# Altium schematic sheet [04] - RAM INTERFACE.SchDoc
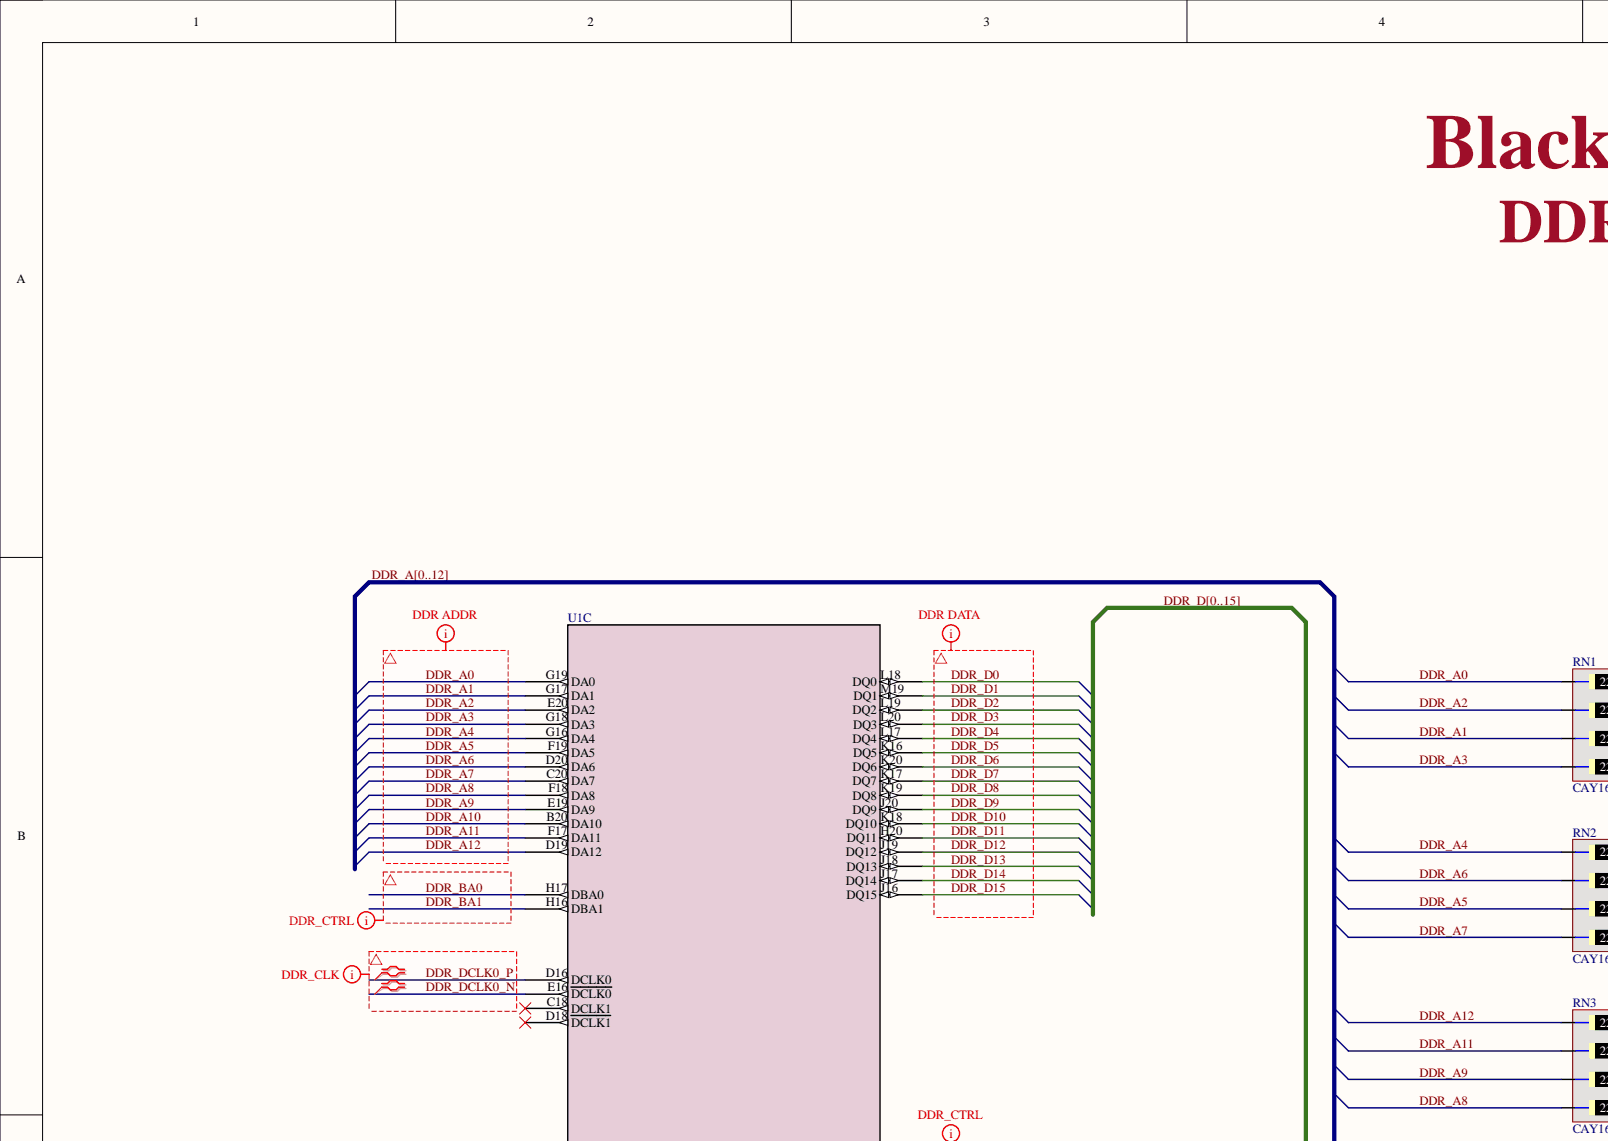
[diagram p0: [04] - RAM INTERFACE.SchDoc, top left]
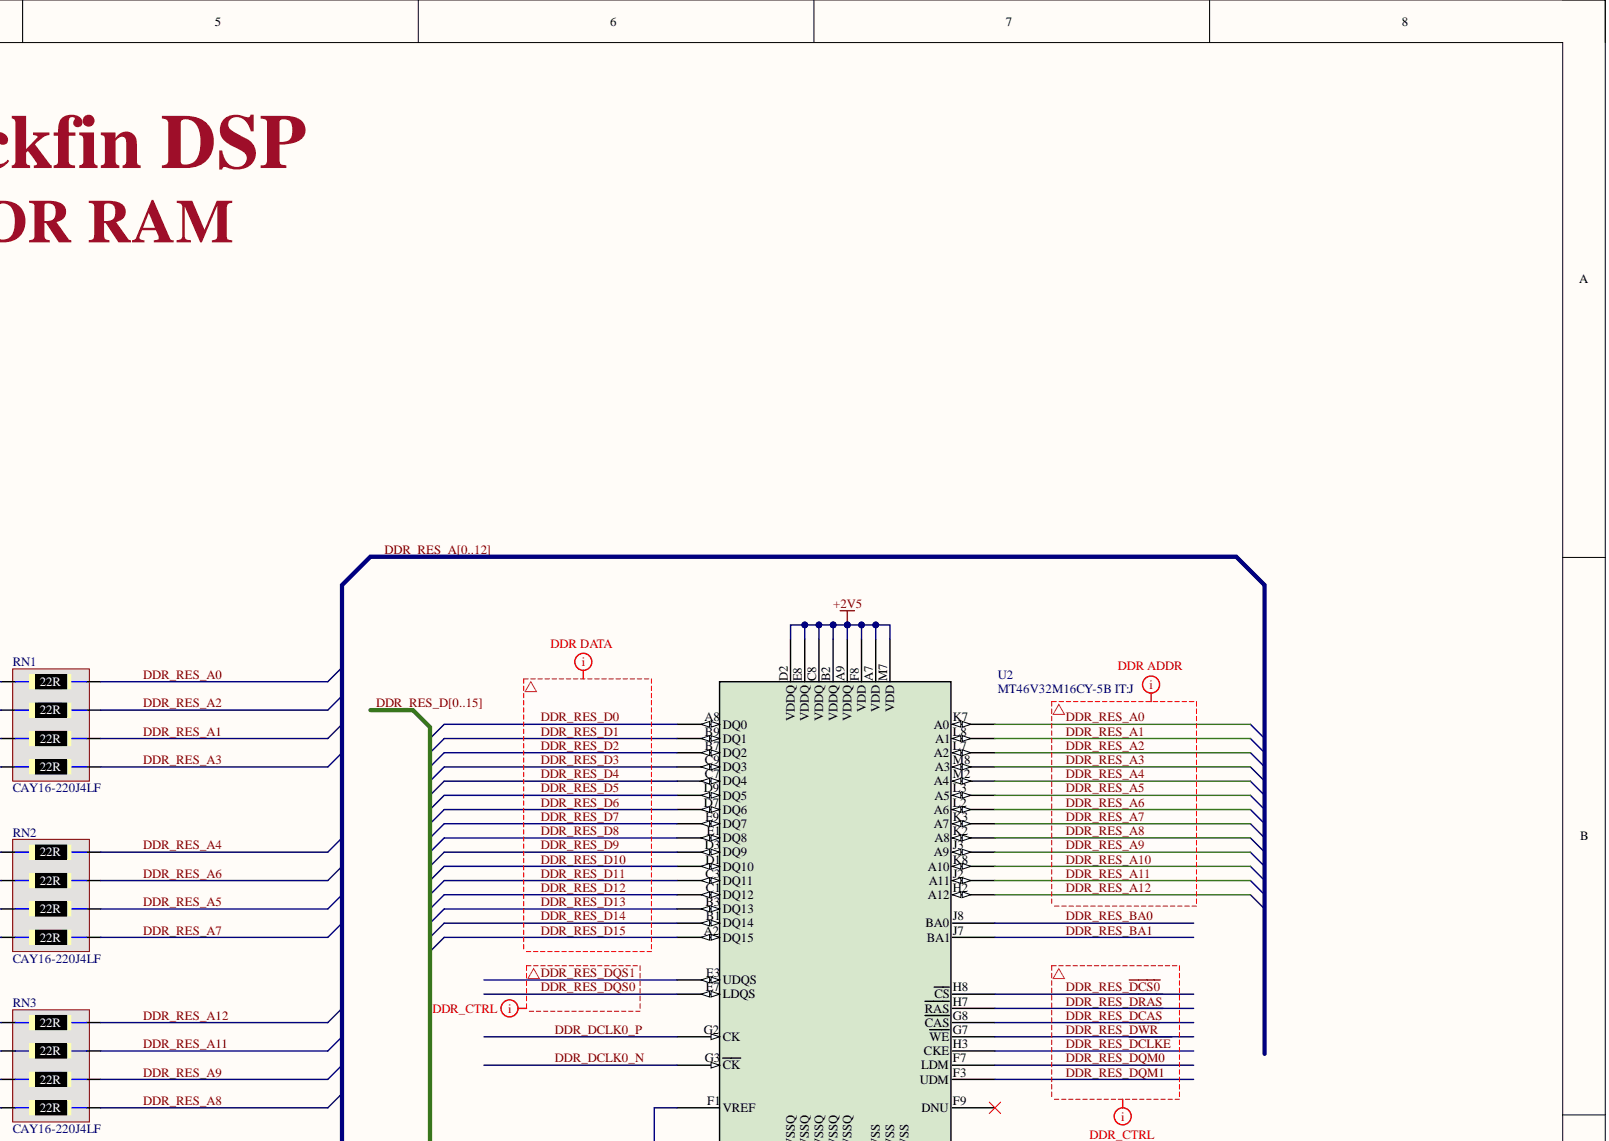
[diagram p1: [04] - RAM INTERFACE.SchDoc, top right]
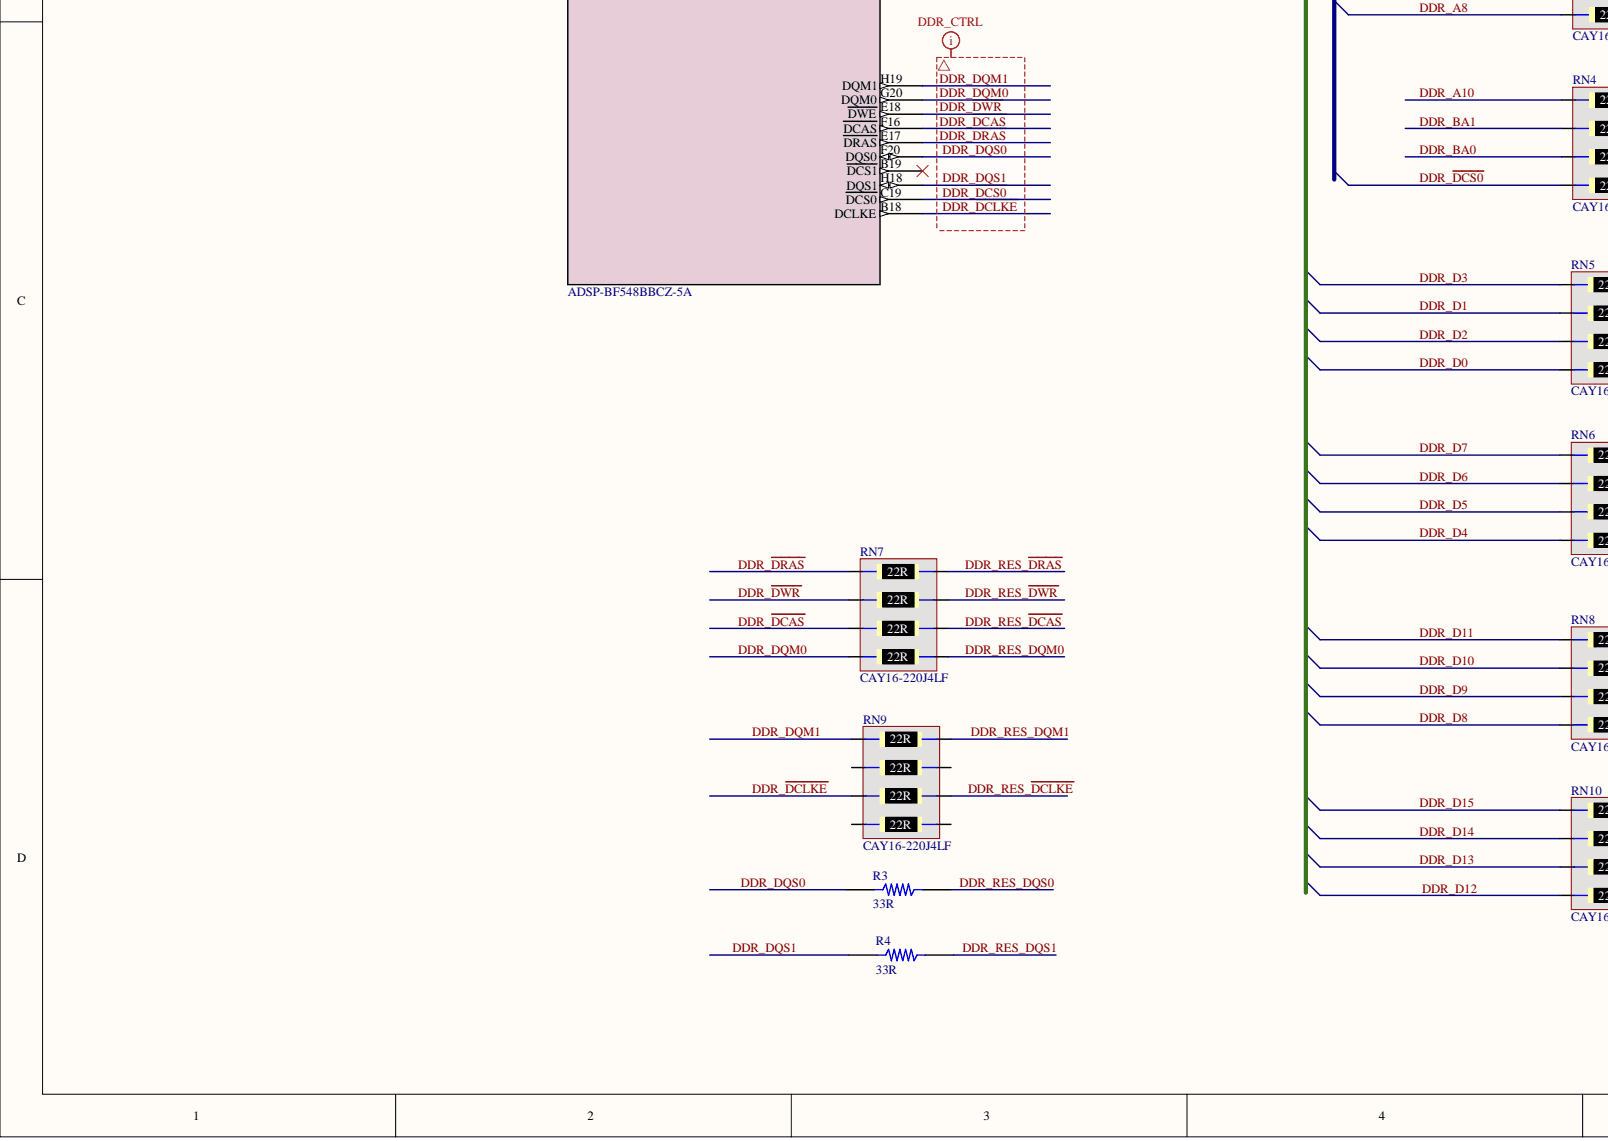
[diagram p2: [04] - RAM INTERFACE.SchDoc, bottom left]
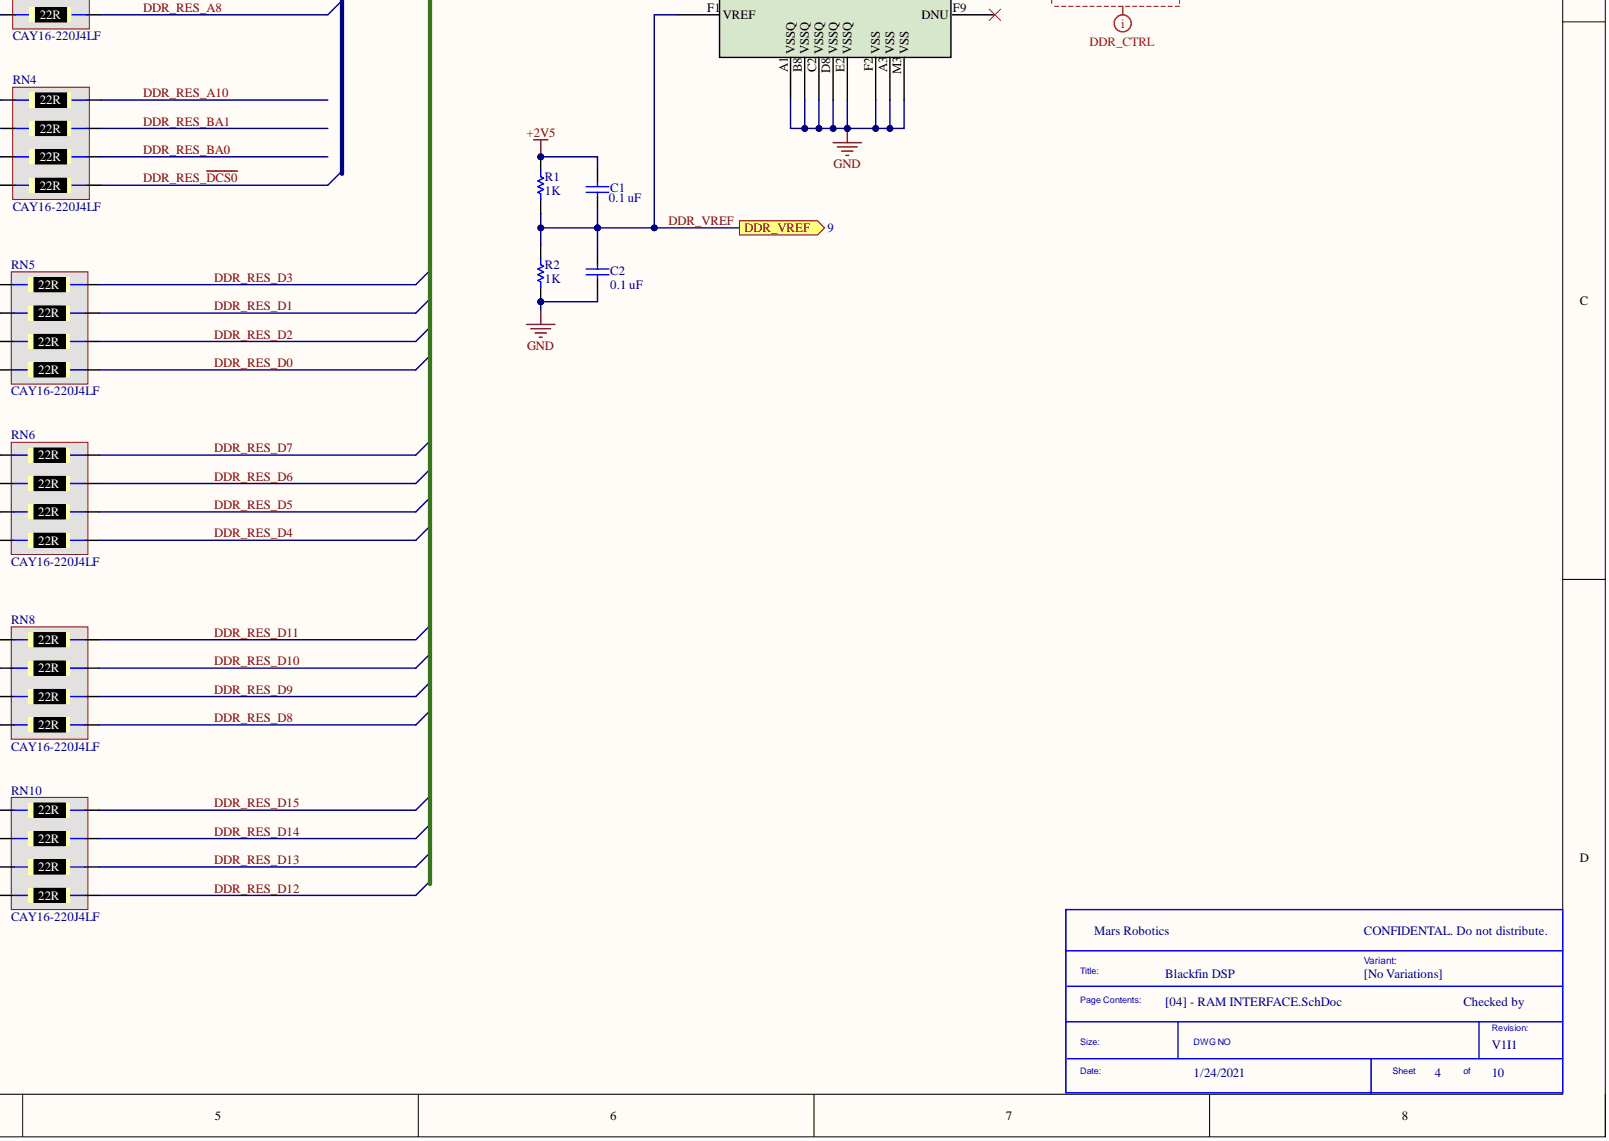
[diagram p3: [04] - RAM INTERFACE.SchDoc, bottom right]

## Parts
C1  0.1 uF  [CAP0402]
C2  0.1 uF  [CAP0402]
R1  1K  [RES0402]
R2  1K  [RES0402]
R3  33R  [RES0402]
R4  33R  [RES0402]
RN1  CAY16-220J4LF  [BO_CAY16]
RN2  CAY16-220J4LF  [BO_CAY16]
RN3  CAY16-220J4LF  [BO_CAY16]
RN4  CAY16-220J4LF  [BO_CAY16]
RN5  CAY16-220J4LF  [BO_CAY16]
RN6  CAY16-220J4LF  [BO_CAY16]
RN7  CAY16-220J4LF  [BO_CAY16]
RN8  CAY16-220J4LF  [BO_CAY16]
RN9  CAY16-220J4LF  [BO_CAY16]
RN10  CAY16-220J4LF  [BO_CAY16]
U1  ADSP-BF548BBCZ-5A  [BC_400_1_ADI]  (part C)
U2  MT46V32M16CY-5B IT:J  [FBGA60_10X12P5_MRN]

## Ports
DDR_VREF

## Nets
+2V5: C1.2 R1.2 U2.A7(VDD) U2.A9(VDDQ) U2.B2(VDDQ) U2.C8(VDDQ) U2.D2(VDDQ) U2.E8(VDDQ) U2.F8(VDD) U2.M7(VDD)
GND: C2.1 R2.1 U2.A1(VSSQ) U2.A3(VSS) U2.B8(VSSQ) U2.C2(VSSQ) U2.D8(VSSQ) U2.E2(VSSQ) U2.F2(VSS) U2.M3(VSS)
DDR_A0: RN1.1(22R) U1.G19(DA0)  [also labelled DDR_A]
DDR_A1: RN1.3(22R) U1.G17(DA1)  [also labelled DDR_A]
DDR_A2: RN1.2(22R) U1.E20(DA2)  [also labelled DDR_A]
DDR_A3: RN1.4(22R) U1.G18(DA3)  [also labelled DDR_A]
DDR_A4: RN2.1(22R) U1.G16(DA4)  [also labelled DDR_A]
DDR_A5: RN2.3(22R) U1.F19(DA5)  [also labelled DDR_A]
DDR_A6: RN2.2(22R) U1.D20(DA6)  [also labelled DDR_A]
DDR_A7: RN2.4(22R) U1.C20(DA7)  [also labelled DDR_A]
DDR_A8: RN3.4(22R) U1.F18(DA8)  [also labelled DDR_A]
DDR_A9: RN3.3(22R) U1.E19(DA9)  [also labelled DDR_A]
DDR_A10: RN4.1(22R) U1.B20(DA10)  [also labelled DDR_A]
DDR_A11: RN3.2(22R) U1.F17(DA11)  [also labelled DDR_A]
DDR_A12: RN3.1(22R) U1.D19(DA12)  [also labelled DDR_A]
DDR_BA0: RN4.3(22R) U1.H17(DBA0)
DDR_BA1: RN4.2(22R) U1.H16(DBA1)
DDR_D0: RN5.4(22R) U1.L18(DQ0)  [also labelled DDR_D]
DDR_D1: RN5.2(22R) U1.M19(DQ1)  [also labelled DDR_D]
DDR_D2: RN5.3(22R) U1.L19(DQ2)  [also labelled DDR_D]
DDR_D3: RN5.1(22R) U1.L20(DQ3)  [also labelled DDR_D]
DDR_D4: RN6.4(22R) U1.L17(DQ4)  [also labelled DDR_D]
DDR_D5: RN6.3(22R) U1.K16(DQ5)  [also labelled DDR_D]
DDR_D6: RN6.2(22R) U1.K20(DQ6)  [also labelled DDR_D]
DDR_D7: RN6.1(22R) U1.K17(DQ7)  [also labelled DDR_D]
DDR_D8: RN8.4(22R) U1.K19(DQ8)  [also labelled DDR_D]
DDR_D9: RN8.3(22R) U1.J20(DQ9)  [also labelled DDR_D]
DDR_D10: RN8.2(22R) U1.K18(DQ10)  [also labelled DDR_D]
DDR_D11: RN8.1(22R) U1.H20(DQ11)  [also labelled DDR_D]
DDR_D12: RN10.4(22R) U1.J19(DQ12)  [also labelled DDR_D]
DDR_D13: RN10.3(22R) U1.J18(DQ13)  [also labelled DDR_D]
DDR_D14: RN10.2(22R) U1.J17(DQ14)  [also labelled DDR_D]
DDR_D15: RN10.1(22R) U1.J16(DQ15)  [also labelled DDR_D]
DDR_~{DCAS}: RN7.3(22R) U1.F16(DCAS)
DDR_~{DCLKE}: RN9.3(22R) U1.B18(DCLKE)
DDR_~{DCS0}: RN4.4(22R) U1.C19(DCS0)
DDR_~{DRAS}: RN7.1(22R) U1.E17(DRAS)
DDR_~{DWR}: RN7.2(22R) U1.E18(DWE)
DDR_DCLK0_N: U1.E16(DCLK0) U2.G3(CK)
DDR_DCLK0_P: U1.D16(DCLK0) U2.G2(CK)
DDR_DQM0: RN7.4(22R) U1.G20(DQM0)
DDR_DQM1: RN9.1(22R) U1.H19(DQM1)
DDR_DQS0: R3.1 U1.F20(DQS0)
DDR_DQS1: R4.1 U1.H18(DQS1)
DDR_RES_A0: RN1.8 U2.K7(A0)  [also labelled DDR_RES_A]
DDR_RES_A1: RN1.6 U2.L8(A1)  [also labelled DDR_RES_A]
DDR_RES_A2: RN1.7 U2.L7(A2)  [also labelled DDR_RES_A]
DDR_RES_A3: RN1.5 U2.M8(A3)  [also labelled DDR_RES_A]
DDR_RES_A4: RN2.8 U2.M2(A4)  [also labelled DDR_RES_A]
DDR_RES_A5: RN2.6 U2.L3(A5)  [also labelled DDR_RES_A]
DDR_RES_A6: RN2.7 U2.L2(A6)  [also labelled DDR_RES_A]
DDR_RES_A7: RN2.5 U2.K3(A7)  [also labelled DDR_RES_A]
DDR_RES_A8: RN3.5 U2.K2(A8)  [also labelled DDR_RES_A]
DDR_RES_A9: RN3.6 U2.J3(A9)  [also labelled DDR_RES_A]
DDR_RES_A10: RN4.8 U2.K8(A10)  [also labelled DDR_RES_A]
DDR_RES_A11: RN3.7 U2.J2(A11)  [also labelled DDR_RES_A]
DDR_RES_A12: RN3.8 U2.H2(A12)  [also labelled DDR_RES_A]
DDR_RES_BA0: RN4.6 U2.J8(BA0)
DDR_RES_BA1: RN4.7 U2.J7(BA1)
DDR_RES_D0: RN5.5 U2.A8(DQ0)  [also labelled DDR_RES_D]
DDR_RES_D1: RN5.7 U2.B9(DQ1)  [also labelled DDR_RES_D]
DDR_RES_D2: RN5.6 U2.B7(DQ2)  [also labelled DDR_RES_D]
DDR_RES_D3: RN5.8 U2.C9(DQ3)  [also labelled DDR_RES_D]
DDR_RES_D4: RN6.5 U2.C7(DQ4)  [also labelled DDR_RES_D]
DDR_RES_D5: RN6.6 U2.D9(DQ5)  [also labelled DDR_RES_D]
DDR_RES_D6: RN6.7 U2.D7(DQ6)  [also labelled DDR_RES_D]
DDR_RES_D7: RN6.8 U2.E9(DQ7)  [also labelled DDR_RES_D]
DDR_RES_D8: RN8.5 U2.E1(DQ8)  [also labelled DDR_RES_D]
DDR_RES_D9: RN8.6 U2.D3(DQ9)  [also labelled DDR_RES_D]
DDR_RES_D10: RN8.7 U2.D1(DQ10)  [also labelled DDR_RES_D]
DDR_RES_D11: RN8.8 U2.C3(DQ11)  [also labelled DDR_RES_D]
DDR_RES_D12: RN10.5 U2.C1(DQ12)  [also labelled DDR_RES_D]
DDR_RES_D13: RN10.6 U2.B3(DQ13)  [also labelled DDR_RES_D]
DDR_RES_D14: RN10.7 U2.B1(DQ14)  [also labelled DDR_RES_D]
DDR_RES_D15: RN10.8 U2.A2(DQ15)  [also labelled DDR_RES_D]
DDR_RES_~{DCAS}: RN7.6 U2.G8(CAS)
DDR_RES_~{DCLKE}: RN9.6 U2.H3(CKE)
DDR_RES_~{DCS0}: RN4.5 U2.H8(CS)
DDR_RES_~{DRAS}: RN7.8 U2.H7(RAS)
DDR_RES_~{DWR}: RN7.7 U2.G7(WE)
DDR_RES_DQM0: RN7.5 U2.F7(LDM)
DDR_RES_DQM1: RN9.8 U2.F3(UDM)
DDR_RES_DQS0: R3.2 U2.E7(LDQS)
DDR_RES_DQS1: R4.2 U2.E3(UDQS)
DDR_VREF: C1.1 C2.2 R1.1 R2.2 U2.F1(VREF) ; port DDR_VREF

Not connected: RN9 2(22R) 4(22R) 5 7; U1 B19(DCS1) C18(DCLK1) D18(DCLK1); U2 F9(DNU)

## Library data of the parts
C1 C2 = 0402ZD104KAT2A: Chip Capacitor, 0.1 uF, +/- 10%, 10 V, -55 to 85 degC, 0402 (1005 Metric), RoHS, Tape and Reel; Capacitance: 100 nF; Case\Package: 0402; Type (Capacitors): X5R; Voltage Rating: 10 V; Case Code (Imperial): 0402; Case Code (Metric): 1005; Component Kind: Standard; ComponentLink1Description: Manufacturer URL; ComponentLink1URL: http://www.avx.com/; ComponentLink2Description: Datasheet; ComponentLink2URL: http://datasheets.avx.com/cx5r.pdf; DatasheetVersion: 05/2016; Height: 0.56 mm; Length: 1 mm; Max Operating Temperature: 85 degC; Min Operating Temperature: -55 degC; Mount: Surface Mount; Mounting Technology: Surface Mount; Package Quantity: 1; PackageDescription: 2-Pin Surface Mount Device, Body 1 x 0.5 mm; PackageReference: 0402; Packaging: Cut Tape; Pins: 2; Radiation Hardening: No; REACH SVHC: No SVHC; RoHSCompliant: true; Tolerance: 10%; Voltage Rating (DC): 10 V; Width: 0.5 mm; Library Name: BlackfinSOM.SchLib
R1 R2 = RT0402FRE071KL: 1 kOhms ±1% 0.063W, 1/16W Chip Resistor 0402 (1005 Metric)  Thin Film; Manufacturer: Yageo; Max Power Dissipation: 63mW; Power Rating: 62.5mW; Resistance: 1kR; Temperature Coefficient: 50ppm/°C; Voltage Rating: 50V; Case Code (Imperial): 0402; Case Code (Metric): 1005; Case/Package: 0402; Category: Chip SMD Resistors; Component Kind: Standard; ComponentLink1Description: https://datasheet.ciiva.com/12834/pyu-rt-1-to-0-05-rohs-l-4-12834181.pdf?src-supplier=Mouser; ComponentLink1URL: https://datasheet.ciiva.com/12834/pyu-rt-1-to-0-05-rohs-l-4-12834181.pdf?src-supplier=Mouser; ComponentLink2Description: https://datasheet.ciiva.com/25647/pyu-rt-1-to-0-05-rohs-l-4-25647306.pdf?src-supplier=Digi-Key; ComponentLink2URL: https://datasheet.ciiva.com/25647/pyu-rt-1-to-0-05-rohs-l-4-25647306.pdf?src-supplier=Digi-Key; ComponentLink3Description: https://datasheet.ciiva.com/8896/pyu-rt-1-to-0-05-rohs-l-4-8896188.pdf?src-supplier=Avnet; ComponentLink3URL: https://datasheet.ciiva.com/8896/pyu-rt-1-to-0-05-rohs-l-4-8896188.pdf?src-supplier=Avnet; Contact Plating: Tin,Matte,Lead; Depth: 0.5mm; Features: Moisture Resistant; Height: 0.014inch; Lead Free: Lead Free; Length: 1mm; Manufacturer Part Number: RT0402FRE071KL; Max Operating Temperature: 125°C; Military Standard: Not; Min Operating Temperature: -55°C; Number of Terminations: 2; Package Quantity: 10000; Packaging: Tape and Reel; Part Number: RT0402FRE071KL; Pricing 1: 1=0.10000, 10=0.07000, 100=0.02830, 1000=0.01272, 2500=0.01104, 5000=0.00912 (USD); Radiation Hardening: No; Resistor Type: Thin Film; RoHS: Yes; Stock 1: 115371; Supplier 1: Digi-Key; Termination: SMD/SMT; Tolerance: 1%; Voltage Rating (DC): 50V; Width: 0.02inch; Library Name: BlackfinSOM.SchLib
R3 R4 = CRCW040233R0JNEE: 33 Ohms ±5% 0.063W, 1/16W Chip Resistor 0402 (1005 Metric) Automotive AEC-Q200 Thick Film; Manufacturer: Vishay Dale; Component Kind: Standard; Digi-Key Part Number: CRCW040233R0JNEE-ND; Manufacturer Product Number: CRCW040233R0JNEE; Package / Case: 0402; Resistance: 33R; Supplier: Vishay Dale; Library Name: BlackfinSOM.SchLib
RN1-RN10 = CAY16-220J4LF: 22 Ohm ±5% 62.5mW Power Per Element Isolated Resistor Network/Array ±200ppm/°C 1206 (3216 Metric), Convex, Long Side Terminals; Manufacturer: Bourns Inc.; Component Kind: Standard; Digi-Key Part Number: CAY16-220J4LFDKR-ND; Manufacturer Product Number: CAY16-220J4LF; Power Per Element: 62.5mW; Tolerance: ±5%; Library Name: BlackfinSOM.SchLib
U1 = ADSP-BF548BBCZ-5A: IC DSP 16BIT 533MHZ 400CSBGA; Manufacturer: Analog Devices Inc.; Component Kind: Standard; Manufacturer Product Number: ADSP-BF548BBCZ-5A; Manufacturer_Part_Number: ADSP-BF548BBCZ-5A; Supplier: Analog Devices Inc.; TypeDigi-Key Part Number: ADSP-BF548BBCZ-5A-ND; Library Name: BlackfinSOM.SchLib
U2 = MT46V32M16CY-5B IT:J TR: SDRAM - DDR Memory IC 512Mb (32M x 16) Parallel 200MHz 700ps 60-FBGA (8x12.5); Manufacturer: Micron Technology Inc.; Component Kind: Standard; Digi-Key Part Number: MT46V32M16CY-5BIT:J-ND; Manufacturer Product Number: MT46V32M16CY-5B IT:J; Package / Case: 60-TFBGA; Series: -; Supplier: Micron Technology Inc.; Library Name: BlackfinSOM.SchLib
